# Altium schematic sheet WiFi_Portable_Sensor_Board_C0_Sens-Radio.SchDoc
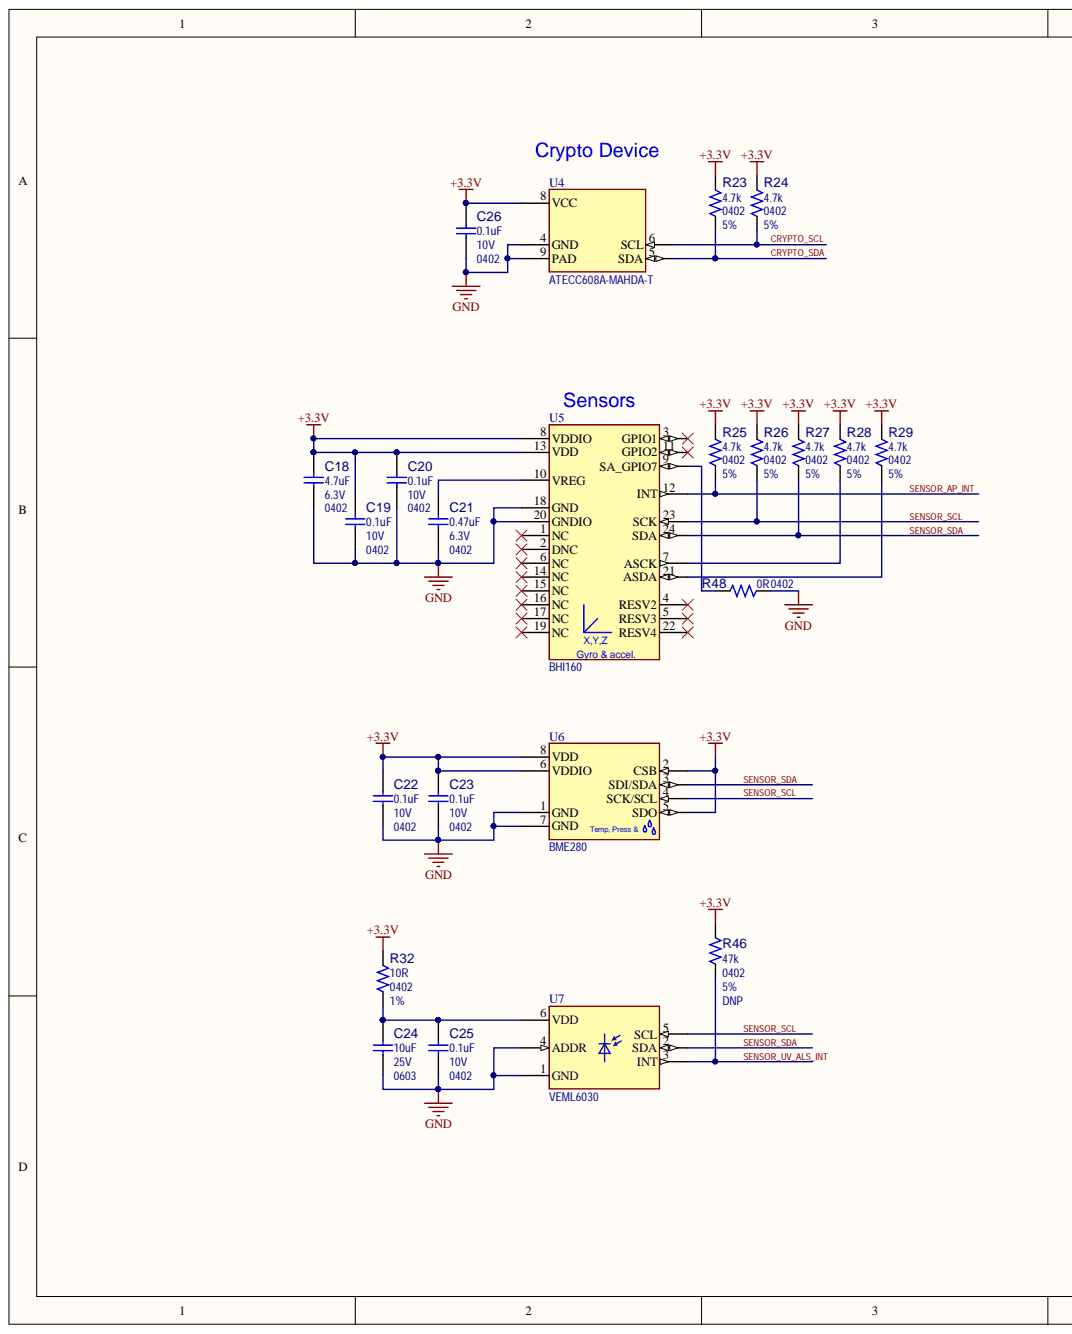
[diagram p0: WiFi_Portable_Sensor_Board_C0_Sens-Radio.SchDoc, left]
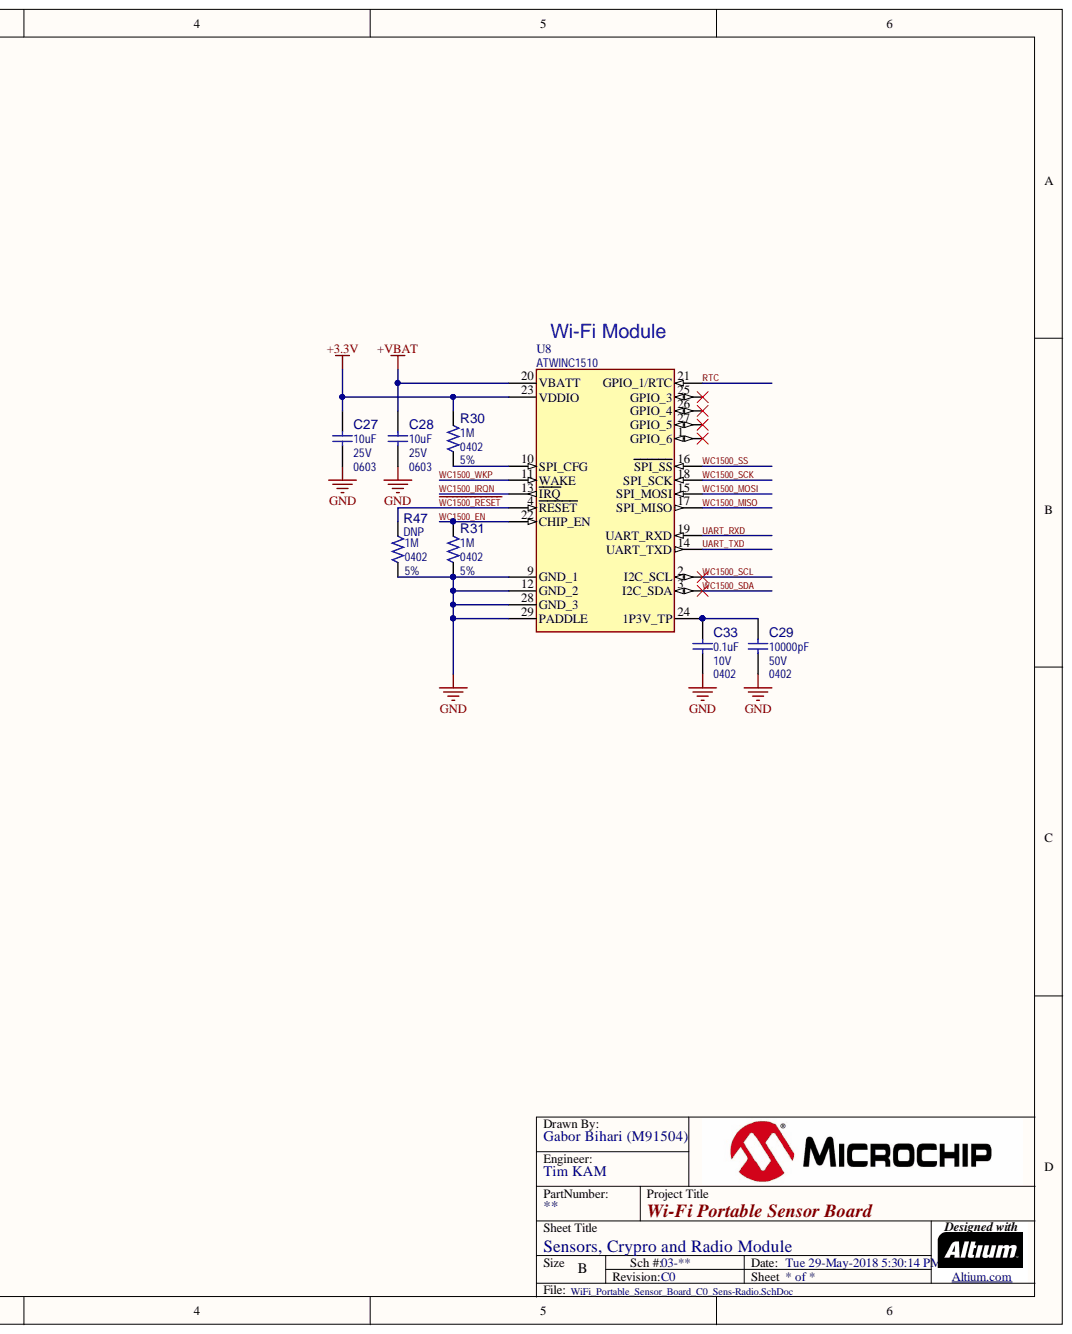
[diagram p1: WiFi_Portable_Sensor_Board_C0_Sens-Radio.SchDoc, right]

## Parts
C18  value 4.7uF  Package: 0402  Voltage: 6.3V  [CAP_C_0402]  (hidden comment: Capacitor NP)
C19  value 0.1uF  Package: 0402  Voltage: 10V  [CAP_C_0402]  (hidden comment: Capacitor NP)
C20  value 0.1uF  Package: 0402  Voltage: 10V  [CAP_C_0402]  (hidden comment: Capacitor NP)
C21  value 0.47uF  Package: 0402  Voltage: 6.3V  [CAP_C_0402]  (hidden comment: Capacitor NP)
C22  value 0.1uF  Package: 0402  Voltage: 10V  [CAP_C_0402]  (hidden comment: Capacitor NP)
C23  value 0.1uF  Package: 0402  Voltage: 10V  [CAP_C_0402]  (hidden comment: Capacitor NP)
C24  value 10uF  Package: 0603  Voltage: 25V  [CAP_C_0603]  (hidden comment: Capacitor NP)
C25  value 0.1uF  Package: 0402  Voltage: 10V  [CAP_C_0402]  (hidden comment: Capacitor NP)
C26  value 0.1uF  Package: 0402  Voltage: 10V  [CAP_C_0402]  (hidden comment: Capacitor NP)
C27  value 10uF  Package: 0603  Voltage: 25V  [CAP_C_0603]  (hidden comment: Capacitor NP)
C28  value 10uF  Package: 0603  Voltage: 25V  [CAP_C_0603]  (hidden comment: Capacitor NP)
C29  value 10000pF  Package: 0402  Voltage: 50V  [CAP_C_0402]  (hidden comment: Capacitor NP)
C33  value 0.1uF  Package: 0402  Voltage: 10V  [CAP_C_0402]  (hidden comment: Capacitor NP)
R23  value 4.7k  Package: 0402  Tolerance: 5%  [RES_C_0402]  (hidden comment: Resistor)
R24  value 4.7k  Package: 0402  Tolerance: 5%  [RES_C_0402]  (hidden comment: Resistor)
R25  value 4.7k  Package: 0402  Tolerance: 5%  [RES_C_0402]  (hidden comment: Resistor)
R26  value 4.7k  Package: 0402  Tolerance: 5%  [RES_C_0402]  (hidden comment: Resistor)
R27  value 4.7k  Package: 0402  Tolerance: 5%  [RES_C_0402]  (hidden comment: Resistor)
R28  value 4.7k  Package: 0402  Tolerance: 5%  [RES_C_0402]  (hidden comment: Resistor)
R29  value 4.7k  Package: 0402  Tolerance: 5%  [RES_C_0402]  (hidden comment: Resistor)
R30  value 1M  Package: 0402  Tolerance: 5%  [RES_C_0402]  (hidden comment: Resistor)
R31  value 1M  Package: 0402  Tolerance: 5%  [RES_C_0402]  (hidden comment: Resistor)
R32  value 10R  Package: 0402  Tolerance: 1%  [RES_C_0402]  (hidden comment: Resistor)
R46  value 47k  Package: 0402  Populated: DNP  Tolerance: 5%  [RES_C_0402]  (hidden comment: Resistor)
R47  value 1M  Package: 0402  Populated: DNP  Tolerance: 5%  [RES_C_0402]  (hidden comment: Resistor)
R48  Resistor  [RES_C_0402]  (value not drawn)
U4  value ATECC608A-MAHDA-T  [UDFN-8_2X3_EP1.5X1.3]  (hidden comment: ATECC508A-MAHCZ-T UDFN-8)
U5  value BHI160  Manufacturer Part Number 1: BHI160  [LGA-24_3X3]  (hidden comment: Sensor Gyro-Accel BHI160 LGA-24)
U6  value BME280  Manufacturer Part Number 1: BME280  [SENSOR_BOSCH_BME280]  (hidden comment: Sensor Ambient Bosch BME280)
U7  value VEML6030  [SENSOR_VISHAY_VEML6030]  (hidden comment: Sensor Light VEML6030 LGA-6)
U8  value ATWINC1510  Type: Wi-Fi  [MOD_ATWINC1500-MR210PB]  (hidden comment: MODULE ATWINC1500-MR210PB)

## Nets
+3.3V: C18.2 C19.2 C20.2 C22.2 C23.2 C26.2 C27.2 R23.2 R24.2 R25.2 R26.2 R27.2 R28.2 R29.2 R30.2 R32.2 R46.2 U4.8(VCC) U5.8(VDDIO) U5.13(VDD) U6.2(CSB) U6.5(SDO) U6.6(VDDIO) U6.8(VDD) U8.23(VDDIO)
+VBAT: C28.2 U8.20(VBATT)
GND: C18.1 C19.1 C20.1 C21.1 C22.1 C23.1 C24.1 C25.1 C26.1 C27.1 C28.1 C29.1 C33.1 R31.1 R47.1 R48.2 U4.4(GND) U4.9(PAD) U5.18(GND) U5.20(GNDIO) U6.1(GND) U6.7(GND) U7.1(GND) U7.4(ADDR) U8.9(GND_1) U8.12(GND_2) U8.28(GND_3) U8.29(PADDLE)
CRYPTO_SCL: R24.1 U4.6(SCL)
CRYPTO_SDA: R23.1 U4.5(SDA)
RTC: U8.21(GPIO_1/RTC)
SENSOR_AP_INT: R25.1 U5.12(INT)
SENSOR_SCL: R26.1 U5.23(SCK) U6.4(SCK/SCL) U7.5(SCL)
SENSOR_SDA: R27.1 U5.24(SDA) U6.3(SDI/SDA) U7.2(SDA)
SENSOR_UV_ALS_INT: R46.1 U7.3(INT)
UART_RXD: U8.19(UART_RXD)
UART_TXD: U8.14(UART_TXD)
~{WC1500_RESET}: R47.2 U8.4(RESET)
WC1500_EN: R31.2 U8.22(CHIP_EN)
WC1500_IRQN: U8.13(IRQ)
WC1500_MISO: U8.17(SPI_MISO)
WC1500_MOSI: U8.15(SPI_MOSI)
WC1500_SCK: U8.18(SPI_SCK)
WC1500_SCL: U8.2(I2C_SCL)
WC1500_SDA: U8.3(I2C_SDA)
WC1500_SS: U8.16(SPI_SS)
WC1500_WKP: U8.11(WAKE)
NetC21_2: C21.2 U5.10(VREG)
NetC24_2: C24.2 C25.2 R32.1 U7.6(VDD)
NetC29_2: C29.2 C33.2 U8.24(1P3V_TP)
NetR28_1: R28.1 U5.7(ASCK)
NetR29_1: R29.1 U5.21(ASDA)
NetR30_1: R30.1 U8.10(SPI_CFG)
NetR48_1: R48.1 U5.9(SA_GPIO7)

Not connected: U5 1(NC) 2(DNC) 3(GPIO1) 4(RESV2) 5(RESV3) 6(NC) 11(GPIO2) 14(NC) 15(NC) 16(NC) 17(NC) 19(NC) 22(RESV4); U8 1(GPIO_6) 25(GPIO_3) 26(GPIO_4) 27(GPIO_5)

## Library data of the parts
C18 = CAP1207: Capacitor; Manufacturer 1: Murata; Manufacturer Part Number 1: GRM155R60J475ME47D; Description_: CAP CER 4.7uF 6.3V 20% X5R SMD 0402; Dev Tools Status: Production; Owner: Tad Ahlvers; Populated: YES; Temperature Coeffcient: X5R; Tolerance: 20%; Type: Ceramic; Category: Capacitor; Component Kind: Standard; ComponentLink1Description: SVN Datasheet; ComponentLink1URL: https://chn-vm-apps.microchip.com/mchprepo/parts/Datasheets/c02e.pdf; ComponentLink2Description: Local Datasheet; ComponentLink3Description: Open Symbol; ComponentLink4Description: Open Footprint; ComponentLink5Description: Open Footprint 2; ComponentLink6Description: Open Footprint 3; ComponentLink7Description: Open Footprint 4; Database Table Name: Capacitor; Library Name: MCHP-CDB.DbLib; Manufacturer 2: TDK Corporation; Manufacturer Part Number 2: C1005X5R0J475M050BC; MCHP-ID: CAP1207; Operating Temperature: -55C to +85C; Quantity Override: 1; Revision: 1; Status: MCL Design; Supplier 1: Digi-Key; Supplier 2: Digi-Key; Supplier Part Number 1: 490-5915-2-ND; Supplier Part Number 2: 445-7395-2-ND; Symbol: Capacitor NP; ComponentLink2URL: C:\ALTIUM_WORK\00001_MCHP-CDB\Datasheets\c02e.pdf; ComponentLink3URL: C:\ALTIUM_WORK\00001_MCHP-CDB\Library\LibraryRefs\Capacitor NP.SchLib; ComponentLink4URL: C:\ALTIUM_WORK\00001_MCHP-CDB\Library\Footprints\CAP_C_0402.PcbLib
C19 C20 C22 C23 C25 C26 C33 = CAP0279: Capacitor; Manufacturer 1: KEMET; Manufacturer Part Number 1: C0402C104K8PACTU; Description_: CAP CER 0.1uF 10V 10% X5R SMD 0402; Dev Tools Status: Production; Populated: Yes; Temperature Coeffcient: X5R; Tolerance: 10%; Type: Ceramic; Category: Capacitor; Component Kind: Standard; ComponentLink1Description: SVN Datasheet; ComponentLink1URL: https://chn-vm-apps.microchip.com/mchprepo/parts/Datasheets/KEM_C1002_X7R_SMD.pdf; ComponentLink2Description: Local Datasheet; ComponentLink3Description: Open Symbol; ComponentLink4Description: Open Footprint; ComponentLink5Description: Open Footprint 2; ComponentLink6Description: Open Footprint 3; ComponentLink7Description: Open Footprint 4; Database Table Name: Capacitor; Library Name: MCHP-CDB.DbLib; Manufacturer 2: Murata; Manufacturer 3: KEMET; Manufacturer Part Number 2: GRM155R61A104KA01D; Manufacturer Part Number 3: C0402C104K8PAC; MCHP-ID: CAP0279; Operating Temperature: -55C to +85C; Quantity Override: 1; Revision: 1; Status: MCL Design; Supplier 1: Digi-Key; Supplier 2: Digi-Key; Supplier 3: KEMET; Supplier Part Number 1: 399-3027-1-ND; Supplier Part Number 2: 490-1318-2-ND; Supplier Part Number 3: C0402C104K8PAC; Symbol: Capacitor NP; ComponentLink2URL: C:\ALTIUM_WORK\00001_MCHP-CDB\Datasheets\KEM_C1002_X7R_SMD.pdf; ComponentLink3URL: C:\ALTIUM_WORK\00001_MCHP-CDB\Library\LibraryRefs\Capacitor NP.SchLib; ComponentLink4URL: C:\ALTIUM_WORK\00001_MCHP-CDB\Library\Footprints\CAP_C_0402.PcbLib
C21 = CAP1364: Capacitor; Manufacturer 1: Murata Electronics North America; Manufacturer Part Number 1: GRM155R60J474KE19D; Description_: CAP CER 0.47uF 6.3V 10% X5R SMD 0402; Dev Tools Status: Production; Owner: Mike Ragsdale; Populated: YES; Temperature Coeffcient: X5R; Tolerance: 10%; Type: Ceramic; Category: Capacitor; Component Kind: Standard; ComponentLink1Description: SVN Datasheet; ComponentLink1URL: https://chn-vm-apps.microchip.com/mchprepo/parts/Datasheets/c02e.pdf; ComponentLink2Description: Local Datasheet; ComponentLink3Description: Open Symbol; ComponentLink4Description: Open Footprint; ComponentLink5Description: Open Footprint 2; ComponentLink6Description: Open Footprint 3; ComponentLink7Description: Open Footprint 4; Database Table Name: Capacitor; Library Name: MCHP-CDB.DbLib; MCHP-ID: CAP1364; Operating Temperature: -55C to +85C; Quantity Override: 1; Revision: 1; Status: MCL Design; Supplier 1: Digi-Key; Supplier Part Number 1: 490-3266-1-ND; Symbol: Capacitor NP; ComponentLink2URL: C:\ALTIUM_WORK\00001_MCHP-CDB\Datasheets\c02e.pdf; ComponentLink3URL: C:\ALTIUM_WORK\00001_MCHP-CDB\Library\LibraryRefs\Capacitor NP.SchLib; ComponentLink4URL: C:\ALTIUM_WORK\00001_MCHP-CDB\Library\Footprints\CAP_C_0402.PcbLib
C24 C27 C28 = CAP1461: Capacitor; Manufacturer 1: Murata Electronics North America; Manufacturer Part Number 1: GRM188R61E106MA73D; Description_: CAP CER 10uF 25V 20% X5R SMD 0603; Dev Tools Status: Production; Owner: Tim Moffat; Populated: YES; Temperature Coeffcient: X5R; Tolerance: 20%; Type: Ceramic; Category: Capacitor; Component Kind: Standard; ComponentLink1Description: SVN Datasheet; ComponentLink1URL: https://chn-vm-apps.microchip.com/mchprepo/parts/Datasheets/GRM188R61E106MA73-01.pdf; ComponentLink2Description: Local Datasheet; ComponentLink3Description: Open Symbol; ComponentLink4Description: Open Footprint; ComponentLink5Description: Open Footprint 2; ComponentLink6Description: Open Footprint 3; ComponentLink7Description: Open Footprint 4; Database Table Name: Capacitor; Library Name: MCHP-CDB.DbLib; MCHP-ID: CAP1461; Operating Temperature: -55C to 85C; Quantity Override: 1; Revision: 1; Status: MCL Design; Supplier 1: Digi-Key; Supplier Part Number 1: 490-7202-1-ND; Symbol: Capacitor NP; ComponentLink2URL: C:\ALTIUM_WORK\00001_MCHP-CDB\Datasheets\GRM188R61E106MA73-01.pdf; ComponentLink3URL: C:\ALTIUM_WORK\00001_MCHP-CDB\Library\LibraryRefs\Capacitor NP.SchLib; ComponentLink4URL: C:\ALTIUM_WORK\00001_MCHP-CDB\Library\Footprints\CAP_C_0603.PcbLib
C29 = CAP1071: Capacitor; Manufacturer 1: Murata; Manufacturer Part Number 1: GRM155R71E103KA01D; Description_: CAP CER 10000pF 50V 10% X7R SMD 0402; Dev Tools Status: Production; Owner: Tad Ahlvers; Populated: YES; Temperature Coeffcient: X7R; Tolerance: 10%; Type: Ceramic; Category: Capacitor; Component Kind: Standard; ComponentLink1Description: SVN Datasheet; ComponentLink1URL: https://chn-vm-apps.microchip.com/mchprepo/parts/Datasheets/UPY-GPHC_X7R_6.3V-to-50V_8.pdf; ComponentLink2Description: Local Datasheet; ComponentLink3Description: Open Symbol; ComponentLink4Description: Open Footprint; ComponentLink5Description: Open Footprint 2; ComponentLink6Description: Open Footprint 3; ComponentLink7Description: Open Footprint 4; Database Table Name: Capacitor; Library Name: MCHP-CDB.DbLib; Manufacturer 2: Yageo; Manufacturer Part Number 2: CC0402KRX7R9BB103; MCHP-ID: CAP1071; Operating Temperature: -55C to +125C; Quantity Override: 1; Revision: 2; Status: MCL Design; Supplier 1: Digi-Key; Supplier 2: Digi-Key; Supplier Part Number 1: 490-1312-2-ND; Supplier Part Number 2: 311-1349-2-ND; Symbol: Capacitor NP; ComponentLink2URL: C:\ALTIUM_WORK\00001_MCHP-CDB\Datasheets\UPY-GPHC_X7R_6.3V-to-50V_8.pdf; ComponentLink3URL: C:\ALTIUM_WORK\00001_MCHP-CDB\Library\LibraryRefs\Capacitor NP.SchLib; ComponentLink4URL: C:\ALTIUM_WORK\00001_MCHP-CDB\Library\Footprints\CAP_C_0402.PcbLib
R23-R29 = RSMT0734: Manufacturer 1: Panasonic; Manufacturer Part Number 1: ERJ-2GEJ472X; Description_: RES TKF 4.7k 5% 1/10W SMD 0402; Dev Tools Status: Production; Populated: Yes; Power: 1/10W; Temperature Coeffcient: 200ppm/C; Voltage: 50V; Category: Resistor; Component Kind: Standard; ComponentLink1Description: SVN Datasheet; ComponentLink1URL: https://chn-vm-apps.microchip.com/mchprepo/parts/Datasheets/AOA0000CE1.pdf; ComponentLink2Description: Local Datasheet; ComponentLink3Description: Open Symbol; ComponentLink4Description: Open Footprint; ComponentLink5Description: Open Footprint 2; ComponentLink6Description: Open Footprint 3; ComponentLink7Description: Open Footprint 4; Composition: TKF; Database Table Name: Resistor; Description: Resistor; Library Name: MCHP-CDB.DbLib; Manufacturer 2: Yageo; Manufacturer Part Number 2: RC0402JR-074K7L; MCHP-ID: RSMT0734; Operating Temperature: -55C to +155C; Quantity Override: 1; Revision: 1; Status: MCL Design; Supplier 1: Digi-Key; Supplier 2: Digi-Key; Supplier Part Number 1: P4.7KJCT-ND; Supplier Part Number 2: 311-4.7KJRTR-ND; Symbol: Resistor; Type: RES SMD; ComponentLink2URL: C:\ALTIUM_WORK\00001_MCHP-CDB\Datasheets\AOA0000CE1.pdf; ComponentLink3URL: C:\ALTIUM_WORK\00001_MCHP-CDB\Library\LibraryRefs\Resistor.SchLib; ComponentLink4URL: C:\ALTIUM_WORK\00001_MCHP-CDB\Library\Footprints\RES_C_0402.PcbLib
R30 R31 = RES1019: Manufacturer 1: Yageo; Manufacturer Part Number 1: RC0402JR-071ML; Description_: RES TKF 1M 5% 1/16W SMD 0402; Dev Tools Status: Production; Owner: Tad Ahlvers; Populated: YES; Power: 1/16W; Temperature Coeffcient: 100ppm/C; Voltage: 50V; Category: Resistor; Component Kind: Standard; ComponentLink1Description: SVN Datasheet; ComponentLink1URL: https://chn-vm-apps.microchip.com/mchprepo/parts/Datasheets/PYu-RC0402_51_RoHS_L_6.pdf; ComponentLink2Description: Local Datasheet; ComponentLink3Description: Open Symbol; ComponentLink4Description: Open Footprint; ComponentLink5Description: Open Footprint 2; ComponentLink6Description: Open Footprint 3; ComponentLink7Description: Open Footprint 4; Composition: TKF; Database Table Name: Resistor; Description: Resistor; Library Name: MCHP-CDB.DbLib; MCHP-ID: RES1019; Operating Temperature: -55C to +155C; Quantity Override: 1; Revision: 1; Status: MCL Design; Supplier 1: Digi-Key; Supplier Part Number 1: 311-1.0MJRCT-ND; Symbol: Resistor; Type: RES SMD; ComponentLink2URL: C:\ALTIUM_WORK\00001_MCHP-CDB\Datasheets\PYu-RC0402_51_RoHS_L_6.pdf; ComponentLink3URL: C:\ALTIUM_WORK\00001_MCHP-CDB\Library\LibraryRefs\Resistor.SchLib; ComponentLink4URL: C:\ALTIUM_WORK\00001_MCHP-CDB\Library\Footprints\RES_C_0402.PcbLib
R32 = RES1305: Manufacturer 1: ROHM; Manufacturer Part Number 1: MCR01MRTF10R0; Description_: RES TKF 10R 1% 1/16W SMD 0402; Dev Tools Status: Production; Owner: Tad Ahlvers; Populated: YES; Power: 1/16W; Temperature Coeffcient: 100ppm/C; Voltage: 50V; Category: Resistor; Component Kind: Standard; ComponentLink1Description: SVN Datasheet; ComponentLink1URL: https://chn-vm-apps.microchip.com/mchprepo/parts/Datasheets/mcr_g.pdf; ComponentLink2Description: Local Datasheet; ComponentLink3Description: Open Symbol; ComponentLink4Description: Open Footprint; ComponentLink5Description: Open Footprint 2; ComponentLink6Description: Open Footprint 3; ComponentLink7Description: Open Footprint 4; Composition: TKF; Database Table Name: Resistor; Description: Resistor; Library Name: MCHP-CDB.DbLib; MCHP-ID: RES1305; Operating Temperature: -55C to +155C; Quantity Override: 1; Revision: 1; Status: MCL Design; Supplier 1: Digi-Key; Supplier Part Number 1: RHM10.0CDTR-ND; Symbol: Resistor; Type: RES SMD; ComponentLink2URL: C:\ALTIUM_WORK\00001_MCHP-CDB\Datasheets\mcr_g.pdf; ComponentLink3URL: C:\ALTIUM_WORK\00001_MCHP-CDB\Library\LibraryRefs\Resistor.SchLib; ComponentLink4URL: C:\ALTIUM_WORK\00001_MCHP-CDB\Library\Footprints\RES_C_0402.PcbLib
R46 = RES1038: Manufacturer 1: Panasonic; Manufacturer Part Number 1: ERJ-2GEJ473X; Description_: RES TKF 47k 5% 1/10W SMD 0402; Dev Tools Status: Production; Owner: Tad Ahlvers; Power: 1/10W; Temperature Coeffcient: 200ppm/C; Voltage: 50V; Category: Resistor; Component Kind: Standard; ComponentLink1Description: SVN Datasheet; ComponentLink1URL: https://chn-vm-apps.microchip.com/mchprepo/parts/Datasheets/AOA0000CE1.pdf; ComponentLink2Description: Local Datasheet; ComponentLink3Description: Open Symbol; ComponentLink4Description: Open Footprint; ComponentLink5Description: Open Footprint 2; ComponentLink6Description: Open Footprint 3; ComponentLink7Description: Open Footprint 4; Composition: TKF; Database Table Name: Resistor; Description: Resistor; Library Name: MCHP-CDB.DbLib; MCHP-ID: RES1038; Operating Temperature: -55C to +155C; Quantity Override: 1; Revision: 1; Status: MCL Design; Supplier 1: Digi-Key; Supplier Part Number 1: P47KJTR-ND; Symbol: Resistor; Type: RES SMD; ComponentLink2URL: C:\ALTIUM_WORK\00001_MCHP-CDB\Datasheets\AOA0000CE1.pdf; ComponentLink3URL: C:\ALTIUM_WORK\00001_MCHP-CDB\Library\LibraryRefs\Resistor.SchLib; ComponentLink4URL: C:\ALTIUM_WORK\00001_MCHP-CDB\Library\Footprints\RES_C_0402.PcbLib
R47 = RES1019: Manufacturer 1: Yageo; Manufacturer Part Number 1: RC0402JR-071ML; Description_: RES TKF 1M 5% 1/16W SMD 0402; Dev Tools Status: Production; Owner: Tad Ahlvers; Power: 1/16W; Temperature Coeffcient: 100ppm/C; Voltage: 50V; Category: Resistor; Component Kind: Standard; ComponentLink1Description: SVN Datasheet; ComponentLink1URL: https://chn-vm-apps.microchip.com/mchprepo/parts/Datasheets/PYu-RC0402_51_RoHS_L_6.pdf; ComponentLink2Description: Local Datasheet; ComponentLink3Description: Open Symbol; ComponentLink4Description: Open Footprint; ComponentLink5Description: Open Footprint 2; ComponentLink6Description: Open Footprint 3; ComponentLink7Description: Open Footprint 4; Composition: TKF; Database Table Name: Resistor; Description: Resistor; Library Name: MCHP-CDB.DbLib; MCHP-ID: RES1019; Operating Temperature: -55C to +155C; Quantity Override: 1; Revision: 1; Status: MCL Design; Supplier 1: Digi-Key; Supplier Part Number 1: 311-1.0MJRCT-ND; Symbol: Resistor; Type: RES SMD; ComponentLink2URL: C:\ALTIUM_WORK\00001_MCHP-CDB\Datasheets\PYu-RC0402_51_RoHS_L_6.pdf; ComponentLink3URL: C:\ALTIUM_WORK\00001_MCHP-CDB\Library\LibraryRefs\Resistor.SchLib; ComponentLink4URL: C:\ALTIUM_WORK\00001_MCHP-CDB\Library\Footprints\RES_C_0402.PcbLib
R48 = RSMT0730: Manufacturer 1: Panasonic; Manufacturer Part Number 1: ERJ-2GE0R00X; Description_: RES TKF 0R SMD 0402; Dev Tools Status: Production; Populated: Yes; Power: 1/10W; Value: 0R; Category: Resistor; Component Kind: Standard; ComponentLink1Description: SVN Datasheet; ComponentLink1URL: https://chn-vm-apps.microchip.com/mchprepo/parts/Datasheets/AOA0000CE1.pdf; ComponentLink2Description: Local Datasheet; ComponentLink3Description: Open Symbol; ComponentLink4Description: Open Footprint; ComponentLink5Description: Open Footprint 2; ComponentLink6Description: Open Footprint 3; ComponentLink7Description: Open Footprint 4; Composition: TKF; Database Table Name: Resistor; Description: Resistor; Library Name: MCHP-CDB.DbLib; Manufacturer 2: Vishay; Manufacturer Part Number 2: CRCW04020000Z0ED; MCHP-ID: RSMT0730; Operating Temperature: -55C to +155C; Package: 0402; Quantity Override: 1; Revision: 2; Status: MCL Design; Supplier 1: Digi-Key; Supplier 2: Digi-Key; Supplier Part Number 1: P0.0JCT-ND; Supplier Part Number 2: 541-0.0JTR-ND; Symbol: Resistor; Type: RES SMD; ComponentLink2URL: C:\ALTIUM_WORK\00001_MCHP-CDB\Datasheets\AOA0000CE1.pdf; ComponentLink3URL: C:\ALTIUM_WORK\00001_MCHP-CDB\Library\LibraryRefs\Resistor.SchLib; ComponentLink4URL: C:\ALTIUM_WORK\00001_MCHP-CDB\Library\Footprints\RES_C_0402.PcbLib
U4 = MIC6669: Manufacturer 1: Atmel; Manufacturer Part Number 1: ATECC508A-MAHDA-T; Database Table Name: MCHP-Memory; Description_: MCHP MEMORY SERIAL EEPROM CRYPTO AUTH 10kb I2C ATECC508A-MAHDA-T UDFN-8; Dev Tools Status: Design; Owner: Jojie Batislaon; Populated: YES; Category: MCHP-Memory; Component Kind: Standard; ComponentLink1Description: SVN Datasheet; ComponentLink2Description: Local Datasheet; ComponentLink3Description: Open Symbol; ComponentLink4Description: Open Footprint; ComponentLink5Description: Open Footprint 2; ComponentLink6Description: Open Footprint 3; ComponentLink7Description: Open Footprint 4; Library Name: MCHP-CDB.DbLib; MCHP-ID: MIC6669; Quantity Override: 1; Revision: B; Status: MCL Design; Supplier 1: Digi-Key; Supplier Part Number 1: ATECC508A-MAHDA-TCT-ND; Symobl: ATECC508A-MAHCZ-T UDFN-8; Type: Serial EEPROM; ComponentLink3URL: C:\ALTIUM_WORK\00001_MCHP-CDB\Library\LibraryRefs\ATECC508A-MAHCZ-T UDFN-8.SchLib; ComponentLink4URL: C:\ALTIUM_WORK\00001_MCHP-CDB\Library\Footprints\UDFN-8_2X3_EP1.5X1.3.PcbLib
U5 = SNSR1045: Manufacturer 1: Bosch Sensortec; Description_: SENSOR ACCEL/GYRO 3-AXIS I2C BHI160 LGA-24; Dev Tools Status: Design; Measured Unit: Acceleration; Owner: Gabor Bihari; Populated: YES; Category: Sensor; Component Kind: Standard; ComponentLink1Description: SVN Datasheet; ComponentLink1URL: https://chn-vm-apps.microchip.com/mchprepo/parts/Datasheets/BST-BHI160B-DS000-01-Datasheet.pdf; ComponentLink2Description: Local Datasheet; ComponentLink3Description: Open Symbol; ComponentLink4Description: Open Footprint; ComponentLink5Description: Open Footprint 2; ComponentLink6Description: Open Footprint 3; ComponentLink7Description: Open Footprint 4; Database Table Name: Sensor; Library Name: MCHP-CDB.DbLib; MCHP-ID: SNSR1045; Operating Temperature: -40°C to 85°C; Package: LGA-24; Quantity Override: 1; Revision: A; Status: MCL Design; Supplier 1: Digi-Key; Supplier Part Number 1: 828-1067-1-ND; Symbol: Sensor Gyro-Accel BHI160 LGA-24; Type: Accelerometer; ComponentLink2URL: C:\ALTIUM_WORK\00001_MCHP-CDB\Datasheets\BST-BHI160B-DS000-01-Datasheet.pdf; ComponentLink3URL: C:\ALTIUM_WORK\00001_MCHP-CDB\Library\LibraryRefs\Sensor Gyro-Accel BHI160 LGA-24.SchLib; ComponentLink4URL: C:\ALTIUM_WORK\00001_MCHP-CDB\Library\Footprints\LGA-24_3X3.PcbLib
U6 = SNSR1046: Manufacturer 1: Bosch Sensortec; Description_: SENSOR PRESSURE-HUMIDITY-TEMP BME280; Dev Tools Status: Design; Measured Unit: Temp, Pressure, Humidity; Owner: Gabor Bihari; Populated: YES; Category: Sensor; Component Kind: Standard; ComponentLink1Description: SVN Datasheet; ComponentLink1URL: https://chn-vm-apps.microchip.com/mchprepo/parts/Datasheets/BST-BME280_DS001-11.pdf; ComponentLink2Description: Local Datasheet; ComponentLink3Description: Open Symbol; ComponentLink4Description: Open Footprint; ComponentLink5Description: Open Footprint 2; ComponentLink6Description: Open Footprint 3; ComponentLink7Description: Open Footprint 4; Database Table Name: Sensor; Library Name: MCHP-CDB.DbLib; MCHP-ID: SNSR1046; Operating Temperature: -40°C to 85°C; Package: MEMS Cavity; Quantity Override: 1; Revision: A; Status: MCL Design; Supplier 1: Digi-Key; Supplier Part Number 1: 828-1063-1-ND; Symbol: Sensor Ambient Bosch BME280; Type: Multi; ComponentLink2URL: C:\ALTIUM_WORK\00001_MCHP-CDB\Datasheets\BST-BME280_DS001-11.pdf; ComponentLink3URL: C:\ALTIUM_WORK\00001_MCHP-CDB\Library\LibraryRefs\Sensor Ambient Bosch BME280.SchLib; ComponentLink4URL: C:\ALTIUM_WORK\00001_MCHP-CDB\Library\Footprints\SENSOR_BOSCH_BME280.PcbLib
U7 = SNSR1047: Manufacturer 1: Vishay Semiconductor Opto Division; Manufacturer Part Number 1: VEML6030-GS15; Description_: SENSOR AMBIENT LIGHT VEML6030; Dev Tools Status: Design; Owner: Gabor Bihari; Populated: YES; Category: Sensor; Component Kind: Standard; ComponentLink1Description: SVN Datasheet; ComponentLink1URL: https://chn-vm-apps.microchip.com/mchprepo/parts/Datasheets/veml6030.pdf; ComponentLink2Description: Local Datasheet; ComponentLink3Description: Open Symbol; ComponentLink4Description: Open Footprint; ComponentLink5Description: Open Footprint 2; ComponentLink6Description: Open Footprint 3; ComponentLink7Description: Open Footprint 4; Database Table Name: Sensor; Library Name: MCHP-CDB.DbLib; MCHP-ID: SNSR1047; Measured Unit: light; Operating Temperature: -25°C to 85°C; Package: LGA-6; Quantity Override: 1; Revision: A; Status: MCL Design; Supplier 1: Digi-Key; Supplier Part Number 1: VEML6030-GS15CT-ND; Symbol: Sensor Light VEML6030 LGA-6; Type: Optical; ComponentLink2URL: C:\ALTIUM_WORK\00001_MCHP-CDB\Datasheets\veml6030.pdf; ComponentLink3URL: C:\ALTIUM_WORK\00001_MCHP-CDB\Library\LibraryRefs\Sensor Light VEML6030 LGA-6.SchLib; ComponentLink4URL: C:\ALTIUM_WORK\00001_MCHP-CDB\Library\Footprints\SENSOR_VISHAY_VEML6030.PcbLib
U8 = MIC6777: Manufacturer 1: Atmel; Manufacturer Part Number 1: ATWINC1510-MR210PB; Database Table Name: MCHP-Wireless; Description_: MCHP RF WI-FI 802.11b/g/n ATWINC1510 MODULE-28; Dev Tools Status: Production; FrequencyRange: 2.412-2.472 MHz; MAPS Description: SmartConnect ATWINC1500B-MU-T Module; MaxSpeedMHz: 0; Owner: Razvan Cristescu; Populated: YES; PowerRX: 70 mA; PowerTX: 172 mA; tblDeviceFamilyID: 11257; Category: MCHP-Wireless; Component Kind: Standard; ComponentLink1Description: SVN Datasheet; ComponentLink1URL: http://www.microchip.com/wwwproducts/Devices.aspx?product=ATWINC1500; ComponentLink2Description: Local Datasheet; ComponentLink3Description: Open Symbol; ComponentLink4Description: Open Footprint; ComponentLink5Description: Open Footprint 2; ComponentLink6Description: Open Footprint 3; ComponentLink7Description: Open Footprint 4; Library Name: MCHP-CDB.DbLib; MCHP-ID: MIC6777; Quantity Override: 1; Revision: 1; RfDataRate: 0; RfPower: 0; Status: MCL Design; Supplier 1: Digi-Key; Supplier Part Number 1: ATWINC1510-MR210PB-ND; Symbol: MODULE ATWINC1500-MR210PB; VDDmax: 0; VDDmin: 0; ComponentLink2URL: C:\ALTIUM_WORK\00001_MCHP-CDB\Datasheets\atmel-42502-smartconnect-winc1500-mr210pb_datasheet.pdf; ComponentLink3URL: C:\ALTIUM_WORK\00001_MCHP-CDB\Library\LibraryRefs\MODULE ATWINC1500-MR210PB.SchLib; ComponentLink4URL: C:\ALTIUM_WORK\00001_MCHP-CDB\Library\Footprints\MOD_ATWINC1500-MR210PB.PcbLib
